# Altium schematic sheet High Voltage Digital Pots for T to E.SchDoc("High Voltage Digital Pots for T to E")
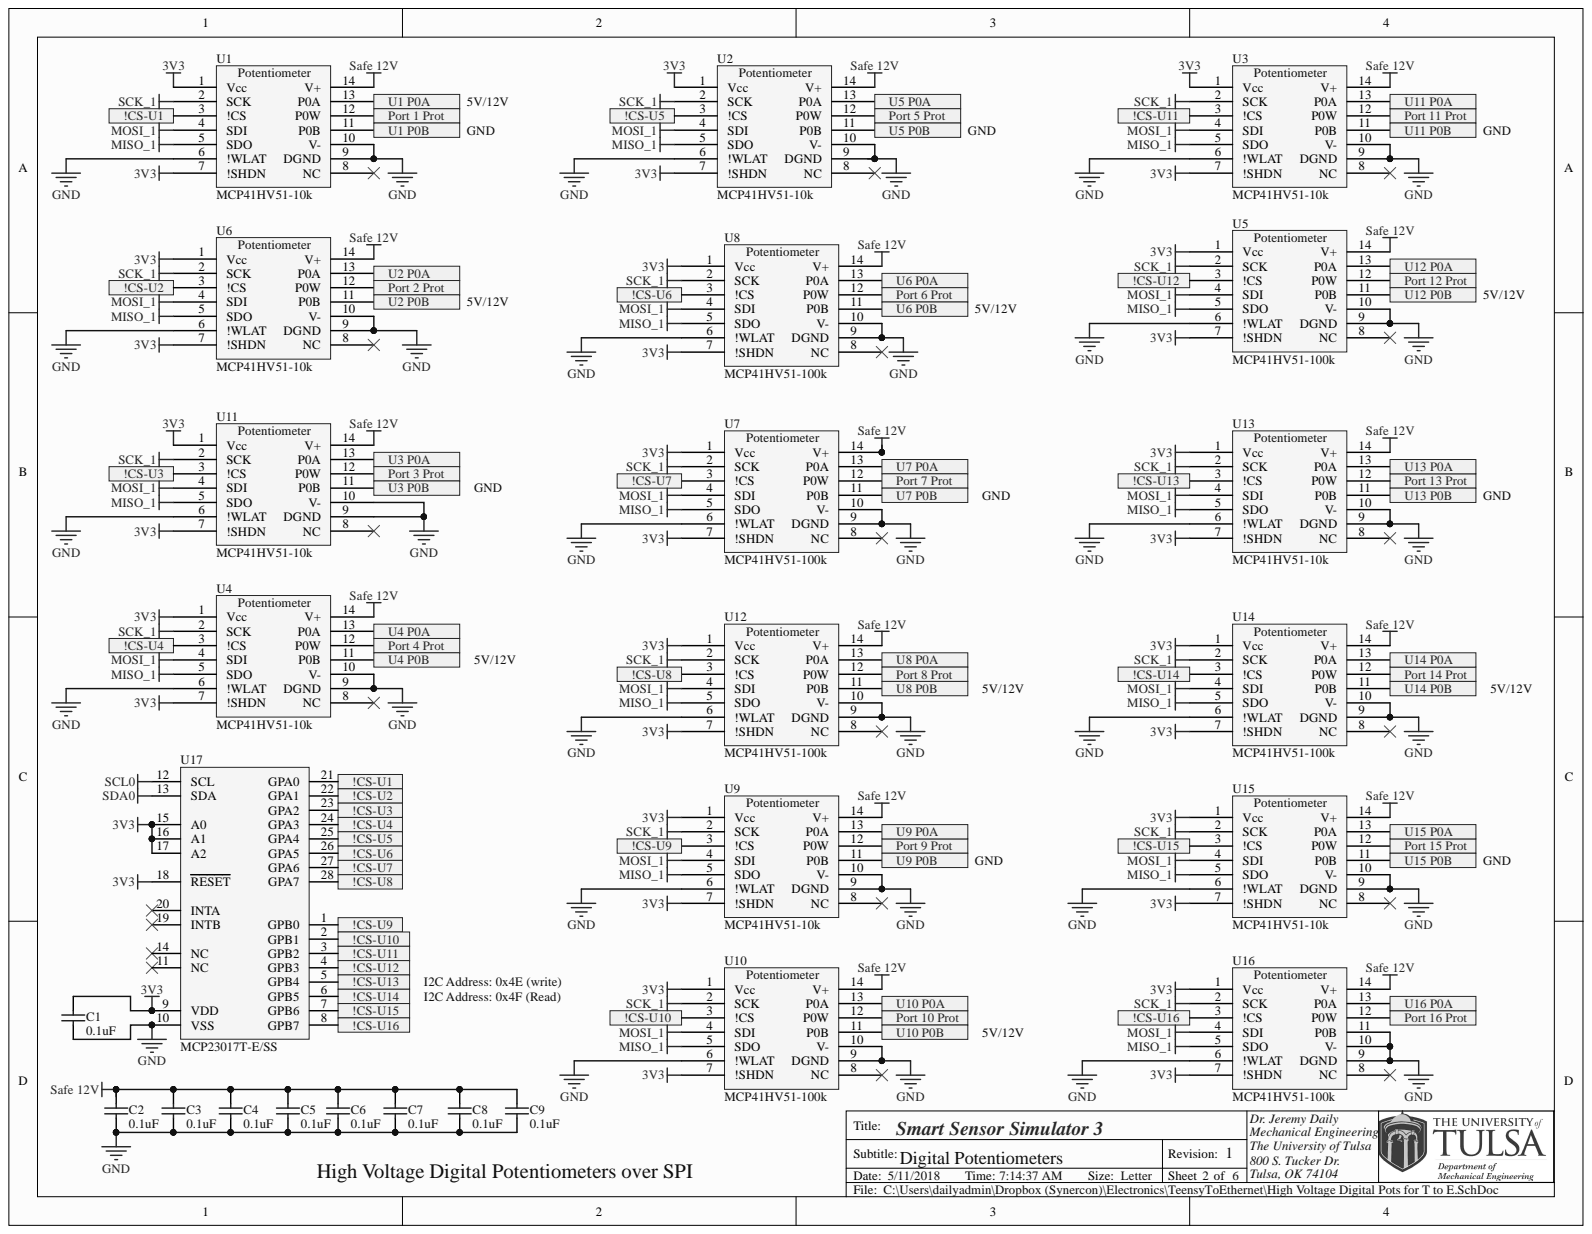
[diagram p0: High Voltage Digital Pots for T to E.SchDoc]

## Parts
C1  0.1uF  [0603]
C2  0.1uF  [0603]
C3  0.1uF  [0603]
C4  0.1uF  [0603]
C5  0.1uF  [0603]
C6  0.1uF  [0603]
C7  0.1uF  [0603]
C8  0.1uF  [0603]
C9  0.1uF  [0603]
U1  MCP41HV51-10k  [TSSOP-ST14_M]
U2  MCP41HV51-10k  [TSSOP-ST14_M]
U3  MCP41HV51-10k  [TSSOP-ST14_M]
U4  MCP41HV51-10k  [TSSOP-ST14_M]
U5  MCP41HV51-100k  [TSSOP-ST14_M]
U6  MCP41HV51-10k  [TSSOP-ST14_M]
U7  MCP41HV51-100k  [TSSOP-ST14_M]
U8  MCP41HV51-100k  [TSSOP-ST14_M]
U9  MCP41HV51-10k  [TSSOP-ST14_M]
U10  MCP41HV51-100k  [TSSOP-ST14_M]
U11  MCP41HV51-10k  [TSSOP-ST14_M]
U12  MCP41HV51-100k  [TSSOP-ST14_M]
U13  MCP41HV51-10k  [TSSOP-ST14_M]
U14  MCP41HV51-100k  [TSSOP-ST14_M]
U15  MCP41HV51-10k  [TSSOP-ST14_M]
U16  MCP41HV51-100k  [TSSOP-ST14_M]
U17  MCP23017T-E/SS  [SSOP-SS28_N]

## Ports
!CS-U1, !CS-U2, !CS-U3, !CS-U4, !CS-U5, !CS-U6, !CS-U7, !CS-U8, !CS-U9, !CS-U10, !CS-U11, !CS-U12, !CS-U13, !CS-U14, !CS-U15, !CS-U16, Port 1 Prot, Port 2 Prot, Port 3 Prot, Port 4 Prot, Port 5 Prot, Port 6 Prot, Port 7 Prot, Port 8 Prot, Port 9 Prot, Port 10 Prot, Port 11 Prot, Port 12 Prot, Port 13 Prot, Port 14 Prot, Port 15 Prot, Port 16 Prot, U1 P0A, U1 P0B, U2 P0A, U2 P0B, U3 P0A, U3 P0B, U4 P0A, U4 P0B, U5 P0A, U5 P0B, U6 P0A, U6 P0B, U7 P0A, U7 P0B, U8 P0A, U8 P0B, U9 P0A, U9 P0B, U10 P0A, U10 P0B, U11 P0A, U11 P0B, U12 P0A, U12 P0B, U13 P0A, U13 P0B, U14 P0A, U14 P0B, U15 P0A, U15 P0B, U16 P0A

## Nets
3V3: C1.2 U1.1(Vcc) U1.7(!SHDN) U2.1(Vcc) U2.7(!SHDN) U3.1(Vcc) U3.7(!SHDN) U4.1(Vcc) U4.7(!SHDN) U5.1(Vcc) U5.7(!SHDN) U6.1(Vcc) U6.7(!SHDN) U7.1(Vcc) U7.7(!SHDN) U8.1(Vcc) U8.7(!SHDN) U9.1(Vcc) U9.7(!SHDN) U10.1(Vcc) U10.7(!SHDN) U11.1(Vcc) U11.7(!SHDN) U12.1(Vcc) U12.7(!SHDN) U13.1(Vcc) U13.7(!SHDN) U14.1(Vcc) U14.7(!SHDN) U15.1(Vcc) U15.7(!SHDN) U16.1(Vcc) U16.7(!SHDN) U17.9(VDD) U17.15(A0) U17.16(A1) U17.17(A2) U17.18(RESET)
GND: C1.1 C2.1 C3.1 C4.1 C5.1 C6.1 C7.1 C8.1 C9.1 U1.6(!WLAT) U1.9(DGND) U1.10(V-) U2.6(!WLAT) U2.9(DGND) U2.10(V-) U3.6(!WLAT) U3.9(DGND) U3.10(V-) U4.6(!WLAT) U4.9(DGND) U4.10(V-) U5.6(!WLAT) U5.9(DGND) U5.10(V-) U6.6(!WLAT) U6.9(DGND) U6.10(V-) U7.6(!WLAT) U7.9(DGND) U7.10(V-) U8.6(!WLAT) U8.9(DGND) U8.10(V-) U9.6(!WLAT) U9.9(DGND) U9.10(V-) U10.6(!WLAT) U10.9(DGND) U10.10(V-) U11.6(!WLAT) U11.9(DGND) U11.10(V-) U12.6(!WLAT) U12.9(DGND) U12.10(V-) U13.6(!WLAT) U13.9(DGND) U13.10(V-) U14.6(!WLAT) U14.9(DGND) U14.10(V-) U15.6(!WLAT) U15.9(DGND) U15.10(V-) U16.6(!WLAT) U16.9(DGND) U16.10(V-) U16.11(P0B) U17.10(VSS)
MISO_1: U1.5(SDO) U2.5(SDO) U3.5(SDO) U4.5(SDO) U5.5(SDO) U6.5(SDO) U7.5(SDO) U8.5(SDO) U9.5(SDO) U10.5(SDO) U11.5(SDO) U12.5(SDO) U13.5(SDO) U14.5(SDO) U15.5(SDO) U16.5(SDO)
MOSI_1: U1.4(SDI) U2.4(SDI) U3.4(SDI) U4.4(SDI) U5.4(SDI) U6.4(SDI) U7.4(SDI) U8.4(SDI) U9.4(SDI) U10.4(SDI) U11.4(SDI) U12.4(SDI) U13.4(SDI) U14.4(SDI) U15.4(SDI) U16.4(SDI)
Safe 12V: C2.2 C3.2 C4.2 C5.2 C6.2 C7.2 C8.2 C9.2 U1.14(V+) U2.14(V+) U3.14(V+) U4.14(V+) U5.14(V+) U6.14(V+) U7.14(V+) U8.14(V+) U9.14(V+) U10.14(V+) U11.14(V+) U12.14(V+) U13.14(V+) U14.14(V+) U15.14(V+) U16.14(V+)
SCK_1: U1.2(SCK) U2.2(SCK) U3.2(SCK) U4.2(SCK) U5.2(SCK) U6.2(SCK) U7.2(SCK) U8.2(SCK) U9.2(SCK) U10.2(SCK) U11.2(SCK) U12.2(SCK) U13.2(SCK) U14.2(SCK) U15.2(SCK) U16.2(SCK)
SCL0: U17.12(SCL)
SDA0: U17.13(SDA)
!CS-U1: U1.3(!CS) U17.21(GPA0) ; port !CS-U1
!CS-U2: U6.3(!CS) U17.22(GPA1) ; port !CS-U2
!CS-U3: U11.3(!CS) U17.23(GPA2) ; port !CS-U3
!CS-U4: U4.3(!CS) U17.24(GPA3) ; port !CS-U4
!CS-U5: U2.3(!CS) U17.25(GPA4) ; port !CS-U5
!CS-U6: U8.3(!CS) U17.26(GPA5) ; port !CS-U6
!CS-U7: U7.3(!CS) U17.27(GPA6) ; port !CS-U7
!CS-U8: U12.3(!CS) U17.28(GPA7) ; port !CS-U8
!CS-U9: U9.3(!CS) U17.1(GPB0) ; port !CS-U9
!CS-U10: U10.3(!CS) U17.2(GPB1) ; port !CS-U10
!CS-U11: U3.3(!CS) U17.3(GPB2) ; port !CS-U11
!CS-U12: U5.3(!CS) U17.4(GPB3) ; port !CS-U12
!CS-U13: U13.3(!CS) U17.5(GPB4) ; port !CS-U13
!CS-U14: U14.3(!CS) U17.6(GPB5) ; port !CS-U14
!CS-U15: U15.3(!CS) U17.7(GPB6) ; port !CS-U15
!CS-U16: U16.3(!CS) U17.8(GPB7) ; port !CS-U16
Port 1 Prot: U1.12(P0W) ; port Port 1 Prot
Port 2 Prot: U6.12(P0W) ; port Port 2 Prot
Port 3 Prot: U11.12(P0W) ; port Port 3 Prot
Port 4 Prot: U4.12(P0W) ; port Port 4 Prot
Port 5 Prot: U2.12(P0W) ; port Port 5 Prot
Port 6 Prot: U8.12(P0W) ; port Port 6 Prot
Port 7 Prot: U7.12(P0W) ; port Port 7 Prot
Port 8 Prot: U12.12(P0W) ; port Port 8 Prot
Port 9 Prot: U9.12(P0W) ; port Port 9 Prot
Port 10 Prot: U10.12(P0W) ; port Port 10 Prot
Port 11 Prot: U3.12(P0W) ; port Port 11 Prot
Port 12 Prot: U5.12(P0W) ; port Port 12 Prot
Port 13 Prot: U13.12(P0W) ; port Port 13 Prot
Port 14 Prot: U14.12(P0W) ; port Port 14 Prot
Port 15 Prot: U15.12(P0W) ; port Port 15 Prot
Port 16 Prot: U16.12(P0W) ; port Port 16 Prot
U1 P0A: U1.13(P0A) ; port U1 P0A
U1 P0B: U1.11(P0B) ; port U1 P0B
U2 P0A: U6.13(P0A) ; port U2 P0A
U2 P0B: U6.11(P0B) ; port U2 P0B
U3 P0A: U11.13(P0A) ; port U3 P0A
U3 P0B: U11.11(P0B) ; port U3 P0B
U4 P0A: U4.13(P0A) ; port U4 P0A
U4 P0B: U4.11(P0B) ; port U4 P0B
U5 P0A: U2.13(P0A) ; port U5 P0A
U5 P0B: U2.11(P0B) ; port U5 P0B
U6 P0A: U8.13(P0A) ; port U6 P0A
U6 P0B: U8.11(P0B) ; port U6 P0B
U7 P0A: U7.13(P0A) ; port U7 P0A
U7 P0B: U7.11(P0B) ; port U7 P0B
U8 P0A: U12.13(P0A) ; port U8 P0A
U8 P0B: U12.11(P0B) ; port U8 P0B
U9 P0A: U9.13(P0A) ; port U9 P0A
U9 P0B: U9.11(P0B) ; port U9 P0B
U10 P0A: U10.13(P0A) ; port U10 P0A
U10 P0B: U10.11(P0B) ; port U10 P0B
U11 P0A: U3.13(P0A) ; port U11 P0A
U11 P0B: U3.11(P0B) ; port U11 P0B
U12 P0A: U5.13(P0A) ; port U12 P0A
U12 P0B: U5.11(P0B) ; port U12 P0B
U13 P0A: U13.13(P0A) ; port U13 P0A
U13 P0B: U13.11(P0B) ; port U13 P0B
U14 P0A: U14.13(P0A) ; port U14 P0A
U14 P0B: U14.11(P0B) ; port U14 P0B
U15 P0A: U15.13(P0A) ; port U15 P0A
U15 P0B: U15.11(P0B) ; port U15 P0B
U16 P0A: U16.13(P0A) ; port U16 P0A

Not connected: U1 8(NC); U2 8(NC); U3 8(NC); U4 8(NC); U5 8(NC); U6 8(NC); U7 8(NC); U8 8(NC); U9 8(NC); U10 8(NC); U11 8(NC); U12 8(NC); U13 8(NC); U14 8(NC); U15 8(NC); U16 8(NC); U17 11(NC) 14(NC) 19(INTB) 20(INTA)

## Library data of the parts
C1-C9 = Cap: 0.1µF -20%, +80% 25V Ceramic Capacitor Y5V (F) 0603 (1608 Metric); PartNumber: 06033G104ZAT2A; Manufacturer: AVX Corporation; Alternative: Acceptable; Supplier Part Number 1: 478-1259-1-ND; Supplier 1: Digi-Key; Simulation: CAP; Signal Integrity: Cap; Library Name: SSS Integrated Library.IntLib; Component Kind: Standard
U1-U4 U6 U9 U11 U13 U15 = MCP41HVX1: 7/8-Bit Single, +36V (±18V) Digital POT  with SPI Serial Interface and Volatile Memory; PartNumber: MCP41HV51-103E/ST; Manufacturer: Microchip Technology; Alternative: None; Supplier Part Number 1: MCP41HV51-103E/ST-ND; Supplier 1: Digi-Key; Library Name: SSS Integrated Library.IntLib; Component Kind: Standard
U5 U10 U12 U14 U16 = MCP41HVX1: Digital Potentiometer 100k Ohm 1 Circuit 256 Taps SPI Interface 14-TSSOP; PartNumber: MCP41HV51-104E/ST; Manufacturer: Microchip Technology; Alternative: None; Supplier Part Number 1: MCP41HV51-104E/ST-ND; Supplier 1: Digi-Key; Library Name: SSS Integrated Library.IntLib; Component Kind: Standard
U7 U8 = MCP41HVX1: 7/8-Bit Single, +36V (±18V) Digital POT  with SPI Serial Interface and Volatile Memory; PartNumber: MCP41HV51-104E/ST; Manufacturer: Microchip Technology; Alternative: None; Supplier Part Number 1: MCP41HV51-104E/ST-ND; Supplier 1: Digi-Key; Library Name: SSS Integrated Library.IntLib; Component Kind: Standard
U17 = MCP23017T-E/SS: 16-Bit I/O Expander with Serial Interface, 28-Pin SSOP, Extended Temperature, Tape and Reel; Manufacturer: Microchip; Alternative: None; Supplier Part Number 1: MCP23017T-E/SSCT-ND; Supplier 1: Digi-Key; PartNumber: MCP23017T-E/SS; Library Name: Microchip Interface.IntLib; Component Kind: Standard
